# Altium schematic sheet Sheet1.SchDoc
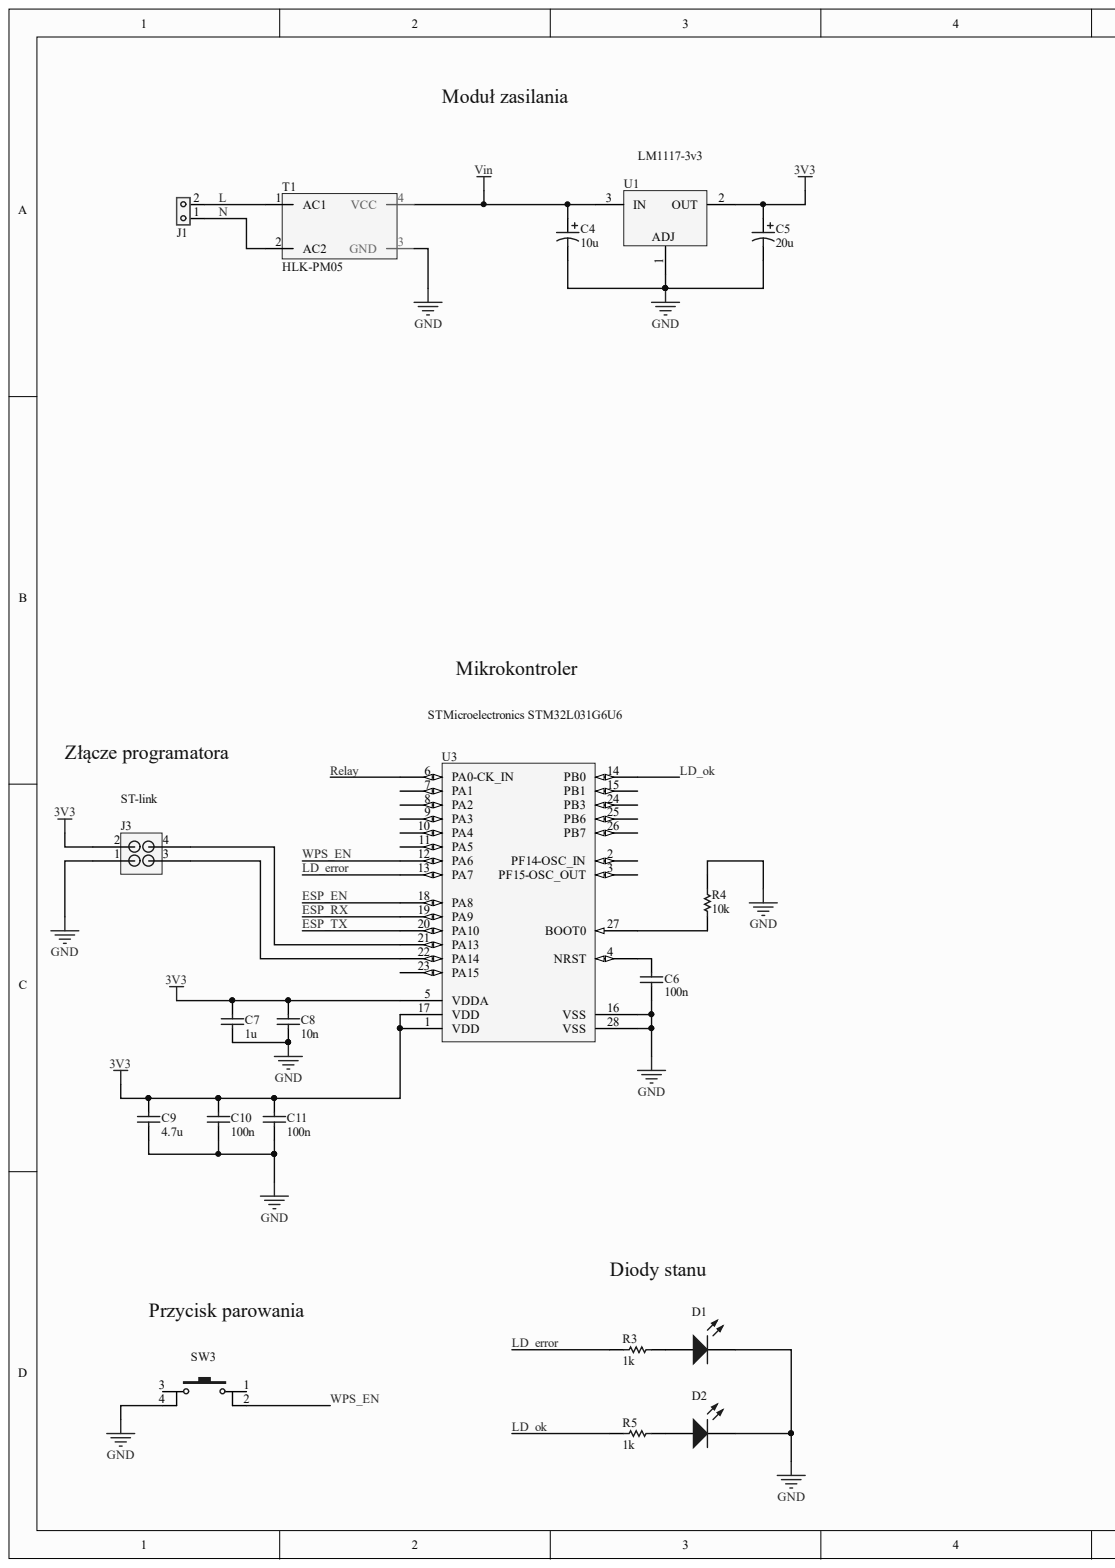
[diagram p0: Sheet1.SchDoc, left]
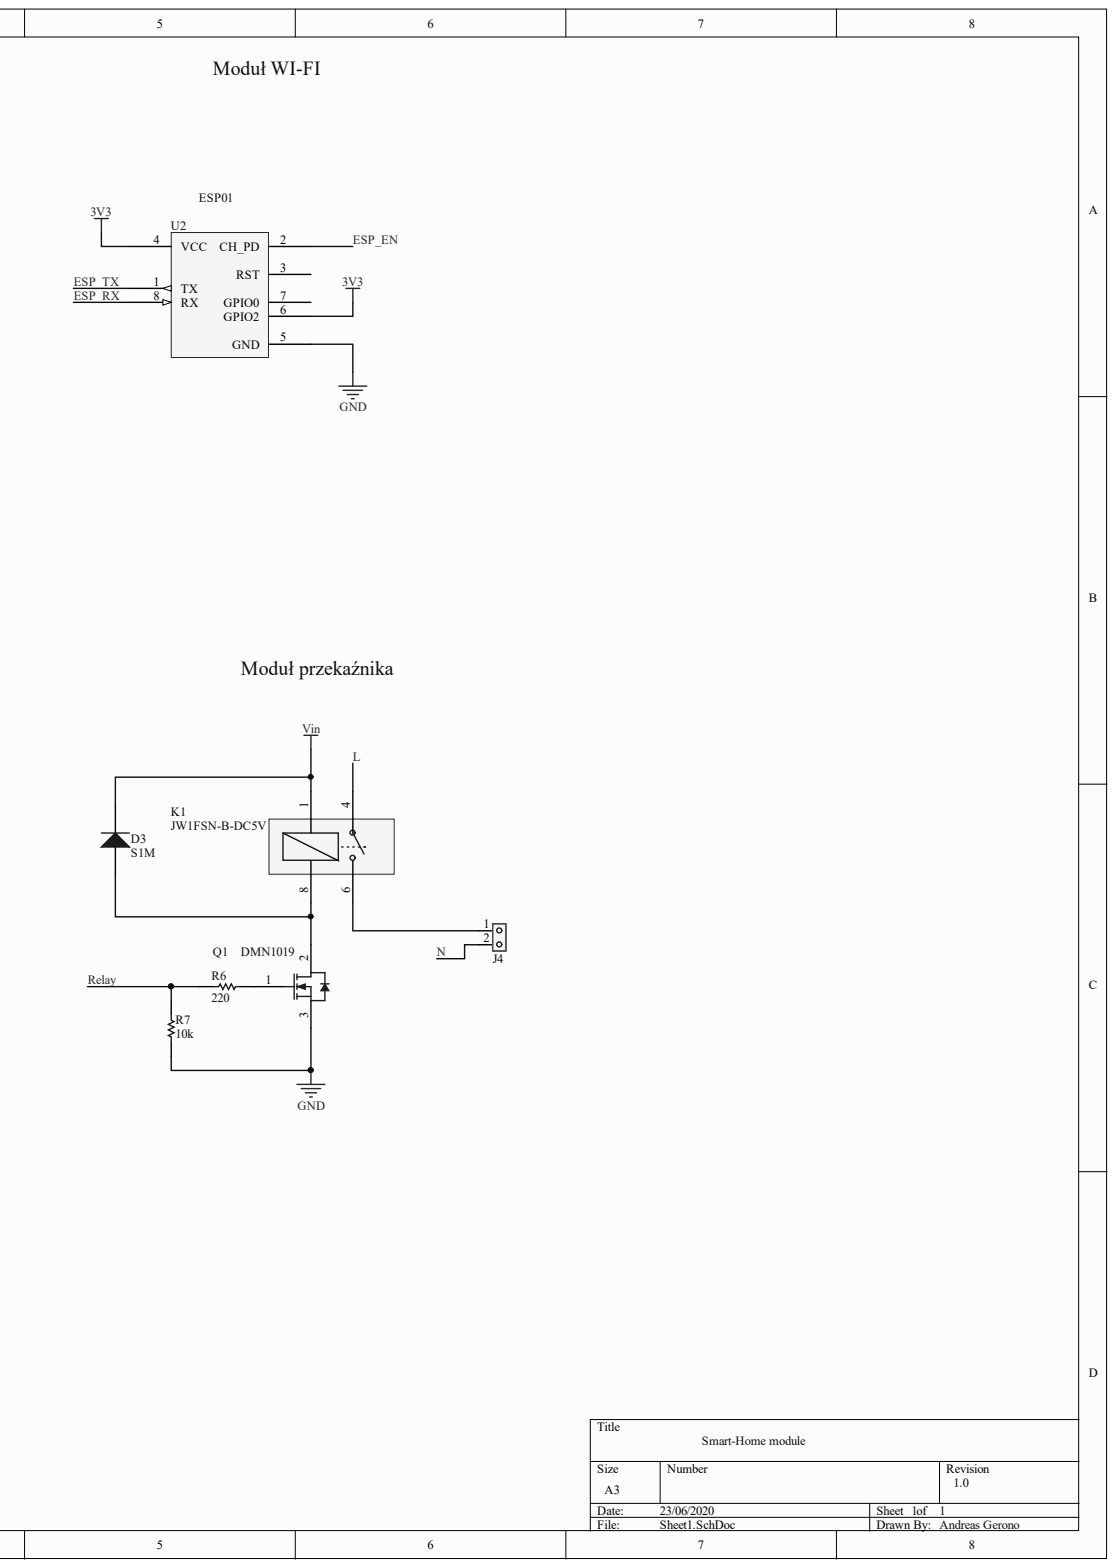
[diagram p1: Sheet1.SchDoc, right]

## Parts
C4  10u  [PCB-z1fsktxgkyvo6s7hhrmp-1]
C5  20u  [PCB-z1fsktxgkyvo6s7hhrmp-1]
C6  100n  [PCB-xukofss9ju753iqcqyy1-1]
C7  1u  [PCB-xukofss9ju753iqcqyy1-1]
C8  10n  [PCB-xukofss9ju753iqcqyy1-1]
C9  4.7u  [PCB-xukofss9ju753iqcqyy1-1]
C10  100n  [PCB-xukofss9ju753iqcqyy1-1]
C11  100n  [PCB-xukofss9ju753iqcqyy1-1]
D1  LED_OK  [PCB-kyhsm20dt5zg9fcr1yq6-1]  (value not drawn)
D2  LED_ERROR  [PCB-kyhsm20dt5zg9fcr1yq6-1]  (value not drawn)
D3  S1M  [PCB-uut559c5purjhrw5ej4e-1]
J1  TE Connectivity 1776275-2  [PCB-vjx0r2b0uvofqus0764v-1]  (value not drawn)
J3  ST-link  [PCB-640863-1]
J4  TE Connectivity 1776275-2  [PCB-vjx0r2b0uvofqus0764v-1]  (value not drawn)
K1  JW1FSN-B-DC5V  [PCB-hjut8nt7er6pd32hhh7w-1]
Q1  DMN1019  [PCB-mq3utp85x1kjpohcsjhk-1]
R3  1k  [RESC1005X40X25NL05T05]
R4  10k  [RESC1005X40X25NL05T05]
R5  1k  [RESC1005X40X25NL05T05]
R6  220  [RESC1005X40X25NL05T05]
R7  10k  [RESC1005X40X25NL05T05]
SW3  (no value)  [PCB-jknttd96a2zks7mphmau-1]
T1  HLK-PM05  [PCB-twnmb28zlj1dzvxq4w3l-1]
U1  LM1117-3v3  [MP04A_N]
U2  ESP01  [PCB-6p6x16zxbitszmgiewj7-1]
U3  STMicroelectronics STM32L031G6U6  [STM32L031G6U6]

## Nets
3V3: C5.1 C7.2 C8.2 C9.2 C10.2 C11.2 J3.2 U1.2 U2.4(VCC) U2.6(GPIO2) U3.1(VDD) U3.5(VDDA) U3.17(VDD)
GND: C4.2 C5.2 C6.1 C7.1 C8.1 C9.1 C10.1 C11.1 D1.2 D2.2 J3.1 Q1.3 R4.1 R7.1 SW3.4 T1.3(GND) U1.1 U2.5(GND) U3.16(VSS) U3.28(VSS)
VIN: C4.1 D3.2 K1.1 T1.4(VCC) U1.3
ESP_EN: U2.2(CH_PD) U3.18(PA8)
ESP_RX: U2.8(RX) U3.19(PA9)
ESP_TX: U2.1(TX) U3.20(PA10)
L: J1.2 K1.4 T1.1(AC1)
LD_ERROR: R3.1 U3.13(PA7)
LD_OK: R5.1 U3.14(PB0)
N: J1.1 J4.2 T1.2(AC2)
RELAY: R6.2 R7.2 U3.6(PA0-CK_IN)
WPS_EN: SW3.2 U3.12(PA6)
NetC6_2: C6.2 U3.4(NRST)
NetD1_1: D1.1 R3.2
NetD2_1: D2.1 R5.2
NetD3_1: D3.1 K1.8 Q1.2
NetJ3_3: J3.3 U3.22(PA14)
NetJ3_4: J3.4 U3.21(PA13)
NetJ4_1: J4.1 K1.6
NetQ1_1: Q1.1 R6.1
NetR4_2: R4.2 U3.27(BOOT0)

Not connected: SW3 1 3; U2 3(RST) 7(GPIO0); U3 2(PF14-OSC_IN) 3(PF15-OSC_OUT) 7(PA1) 8(PA2) 9(PA3) 10(PA4) 11(PA5) 15(PB1) 23(PA15) 24(PB3) 25(PB6) 26(PB7)

## Library data of the parts
C4 C5 = CMP-e2d92bd252d93d1e-2: Cap Tant Solid 10uF 10V A CASE 10% (3.2 X 1.6 X 1.6mm) SMD 3216-18 3.8 Ohm 125C T/R; Manufacturer Part Number: T491A106K010AT; Capacitance: 10.0 µF; Dielectric Material: Tantalum; Equivalent Series Resistance (ESR): 4.00 Ω; Lead-Free Status: Lead Free; Voltage Rating (DC): 10.0 V; Capacitance Tolerance: ±10%; Case/Package: 3216-18; Case/Package (SI): 3216-18; Component Kind: Standard; Library Name: CommunityVault; Mounting Style: Surface Mount; Number of Pins: 2; OctopartID: e2d92bd252d93d1e; Octopart URL: http://octopart.com/t491a106k010at-kemet-103782; Packaging: Tape & Reel (TR), Cut Tape (CT); REACH SVHC Compliance: No SVHC; RoHS: Compliant; Size-Height: 1.60 mm; Size-Length: 3.20 mm; Size-Width: 1.60 mm
C6-C11 = CMP-8262016-10: Capacitor; Ceramic; Cap; .100uF; Tol 10%; SMT; Vol-Rtg 16V; X7R; Tape And Reel; Lead-Free Status: R; Component Kind: Standard; Dielectric Characteristic: X7R; Dielectric Material: Ceramic; Library Name: CommunityVault; OctopartID: f51f3c14bfb72ad8; Packaging: Tape & Reel (TR), Cut Tape (CT); REACH SVHC Compliance: No SVHC; RoHS: Compliant; Size-Height: 500 µm; Size-Width: 500 µm
D1 D2 = CMP-08cfc1c47bdcd708-2: 550 Series Red Ø5 mm 50° 7 mcd Diffused 20 mA 2.2 V LED Circuit Board Indicator; Luminous Intensity: 7.00 mcd; Component Kind: Standard; Lens Type: Diffused; Library Name: CommunityVault; Mounting Style: Through Hole, Vertical; OctopartID: 08cfc1c47bdcd708; Octopart URL: https://octopart.com/550-2404f-dialight-87669; Packaging: Bulk; RoHS: Compliant; Size-Height: 5.84 mm; Size-Length: 6.10 mm; Viewing Angle: 50 °
D3 = CMP-895d42245216569a-7: DIODE, STANDARD, 1A, 1000V; Diode Type: Standard Recovery; Voltage, Vrrm: 1000V; Current, If AV: 1A; Current, Ifsm: 3...; Capacitance: 1.2e-11 F; Current Rating: 1.0 A; Forward Voltage: 1.0 V; Lead-Free Status: Lead Free; Output Current: A; Voltage Rating (DC): 1000.0 V; Case/Package: DO-214; Component Kind: Standard; Library Name: CommunityVault; Lifecycle Status: Not Listed by Manufacturer; Manufacturer Part Number: S1M; Mounting Style: Surface Mount; Number of Pins: 2; OctopartID: 895d42245216569a; Octopart URL: http://octopart.com/s1m-diotec-41156141; Packaging: Cut Tape (CT), Tape & Reel (TR); Polarity: Standard; REACH SVHC Compliance: No SVHC; RoHS: Compliant
J1 J4 = CMP-14c6dfb87408cb01-5: Wire to Board 2 Position 3.5 mm Pitch 26-18 AWG Euro Style Terminal Block; Current Rating: 7.00 A; ELV Compliant: Compliant; Lead-Free Status: Lead Free; Number of Contacts: 2; Pin Pitch: 3.50 mm; Wire Gauge: 18.0 AWG; Component Kind: Standard; Contact Material: Brass; Contact Plating: Tin; Housing Color: Blue; Housing Material: Nylon; Library Name: CommunityVault; Manufacturer Part Number: 1776275-2; OctopartID: 14c6dfb87408cb01; Orientation: Right Angle; Packaging: Bulk; REACH SVHC Compliance: No SVHC
J3 = CMP-640863-7: CONN HEADER VERT 4POS .100 30AU; Component Kind: Standard; Library Name: CommunityVault
K1 = CMP-69e5a2b21d1db622-7: JW Series 10A SPDT 5 VDC Through Hole Sealed Non-Polarized Miniature Power Relay; Carry Current: 10.0 A; Coil Current: 106 mA; Coil Resistance: 47.0 Ω; Coil Voltage: 5.00 V, 5.00 V (max); Lead-Free Status: Lead Free; Component Kind: Standard; Contact Current Rating: 10.0 A; Contact Material: Silver; Contacts Type: SPDT; Library Name: CommunityVault; Lifecycle Status: Active; Manufacturer Part Number: JW1FSN-B-DC5V; Mounting Style: Through Hole; OctopartID: 69e5a2b21d1db622; Octopart URL: http://octopart.com/jw1fsn-b-dc5v-panasonic-39670174; Packaging: Bulk; RoHS: Compliant; Size-Height: 20.0 mm; Size-Length: 28.6 mm; Size-Width: 12.8 mm
Q1 = CMP-b92f72f491c766e8-1: N-Channel 12 V 10 mO 50.6 nC SMT Enhancement Mode Mosfet - SC-59 (SOT-23); Manufacturer Part Number: DMN1019USN-7; Case/Package: TO-236, SOT-23-3, SC-59; Component Kind: Standard; Library Name: CommunityVault; Lifecycle Status: Not Listed by Manufacturer; Mounting Style: Surface Mount; Packaging: Tape & Reel (TR); RoHS: Compliant
R3-R7 = CMP-3653726-2: Thick Film Resistors - SMD 0402 200ohms 5% Tol; Manufacturer Part Number: ERJ-2GEJ201X; Lead-Free Status: Lead Free; Power Rating: 0.1 W; Resistance: 200.0 Ω; Voltage Rating (DC): 50.0 V; Case/Package: 0402; Component Kind: Standard; Library Name: CommunityVault; Lifecycle Status: Not Listed by Manufacturer; Mounting Style: Surface Mount; OctopartID: c9b003f5a2041677; Octopart Url: https://octopart.com/erj-2gej201x-panasonic-55529170; Packaging: Cut Tape (CT); REACH SVHC Compliance: No SVHC; Resistance Tolerance: ±5%; RoHS: Compliant; Size-Height: 0.00035 m; Size-Length: 0.001 m; Size-Width: 0.0005 m
SW3 = CMP-34bcffd9c077eb7b-4: Switch Tactile OFF (ON) SPST Round Button Gull Wing 0.05A 24VDC 1VA 9.18N SMD T/R; Component Kind: Standard; Library Name: CommunityVault
T1 = CMP-038cca8bcf84dc4b-2: HLK-PM01; Component Kind: Standard; Library Name: FavoritesLibrary; OctopartID: 038cca8bcf84dc4b
U1 = CMP-0062-01614-6: 800mA Low-Dropout Linear Regulator, 4-pin SOT-223, Pb-Free; Manufacturer Part Number: LM1117IMP-ADJ/NOPB; Current Rating: 800.0 A; Dropout Voltage: 1.2 V; Lead-Free Status: Lead Free; Number of Outputs: 1; Output Capacitor Type: Tantalum; Output Current: 0.8 A; Quiescent Current: 0.005 A; Case/Package: SOT-223; Component Kind: Standard; Library Name: CommunityVault; Lifecycle Status: Active; Mounting Style: Surface Mount; Number of Pins: 4; Number of Regulated Outputs: 1; OctopartID: e3392b495dc63cfe; Octopart Url: https://octopart.com/lm1117imp-adj%2Fnopb-texas+instruments-24812441; REACH SVHC Compliance: No SVHC; RoHS: Compliant
U2 = CMP-7244bf4cf6cbad83-11: Locking Plug; Grounding; 30A; 277/480V; 3phase; 4P; 5W; NEMA L22-30P; Blk/Wht.; Manufacturer Part Number: 2821; Component Kind: Standard; Library Name: CommunityVault; OctopartID: 7244bf4cf6cbad83; Octopart URL: https://octopart.com/2821-adafruit+industries-67281385; RoHS: Compliant
U3 = CMP-2c38e1247500f3ff-4: MCU 32-Bit STM32L031x6 ARM Cortex M0+ RISC 32KB Flash 3.3V 28-Pin UFQFPN Tray; Component Kind: Standard; Library Name: CommunityVault
